# Altium schematic sheet GOST_A3_2 (1).SchDot
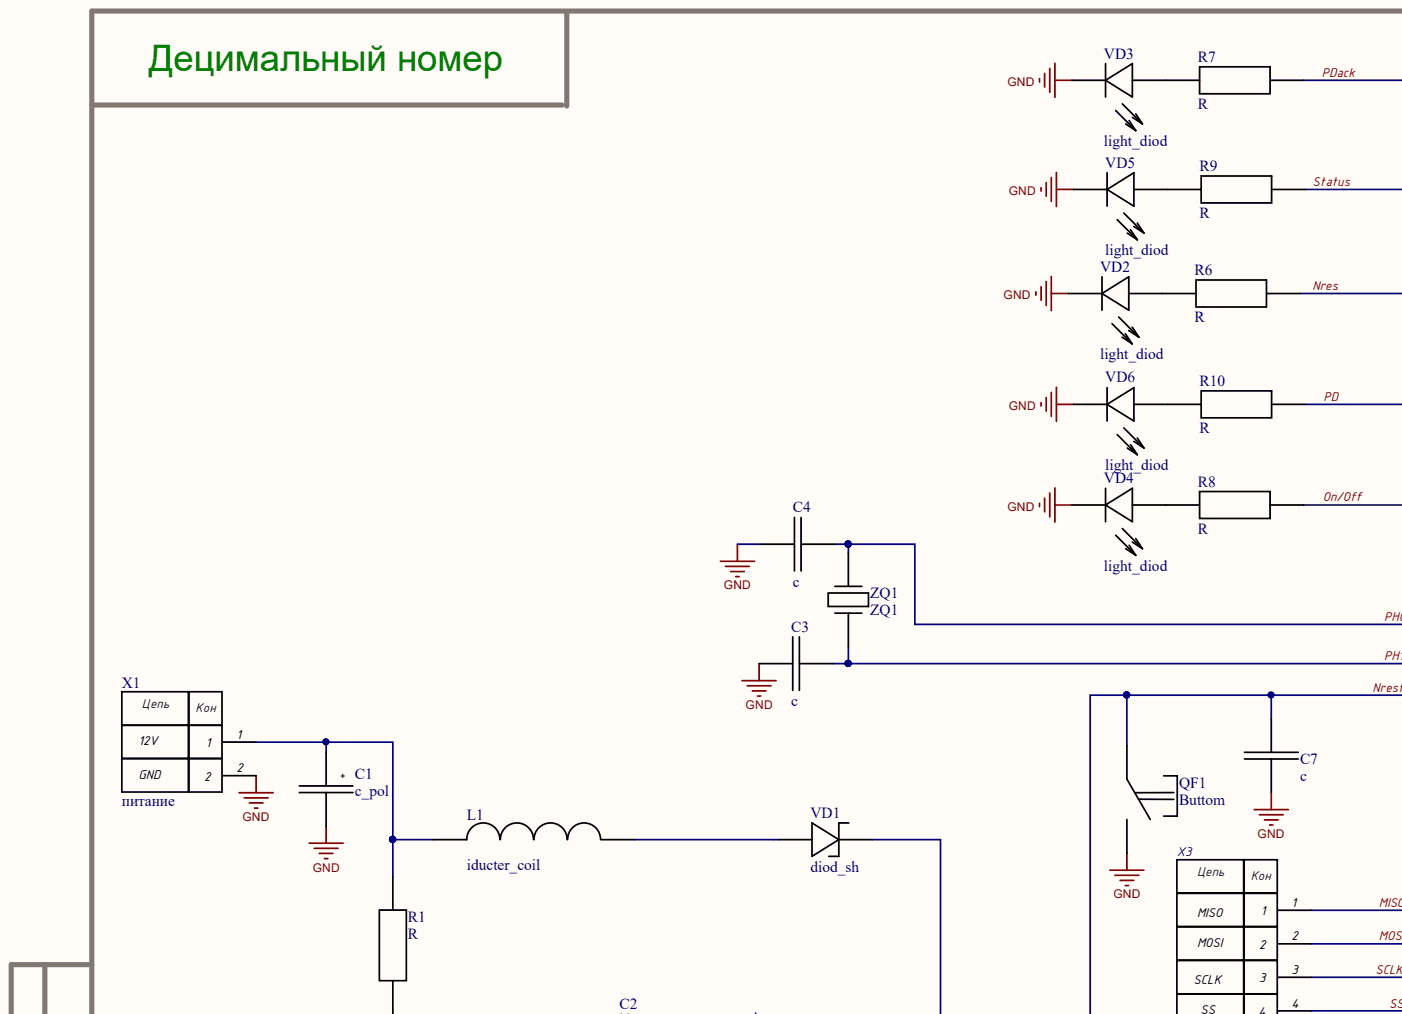
[diagram p0: GOST_A3_2 (1).SchDot, top left]
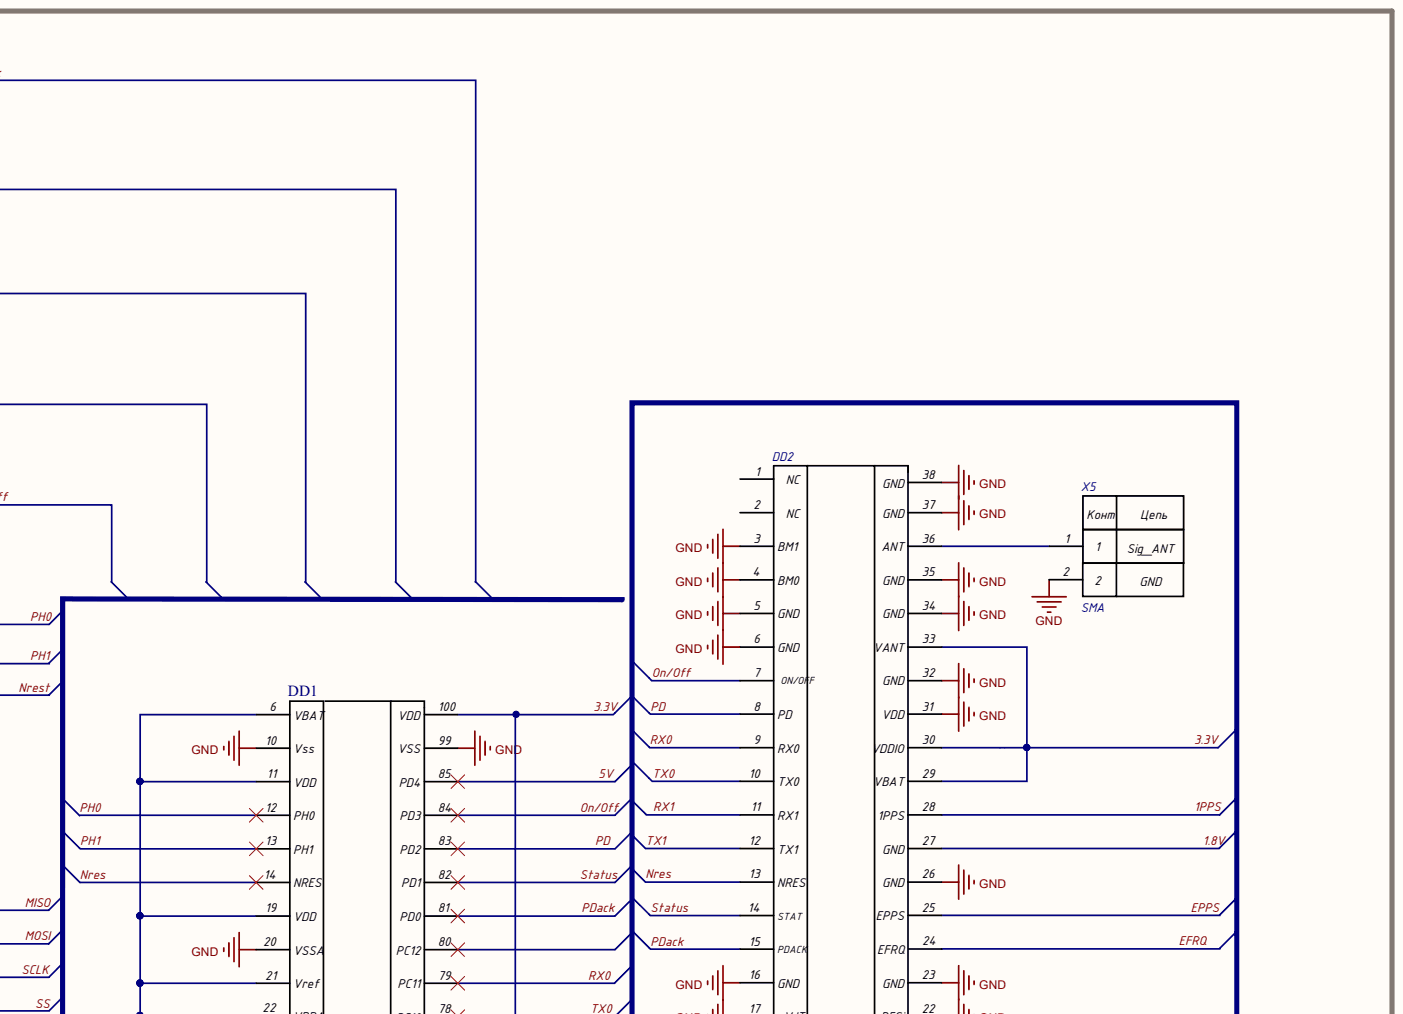
[diagram p1: GOST_A3_2 (1).SchDot, top right]
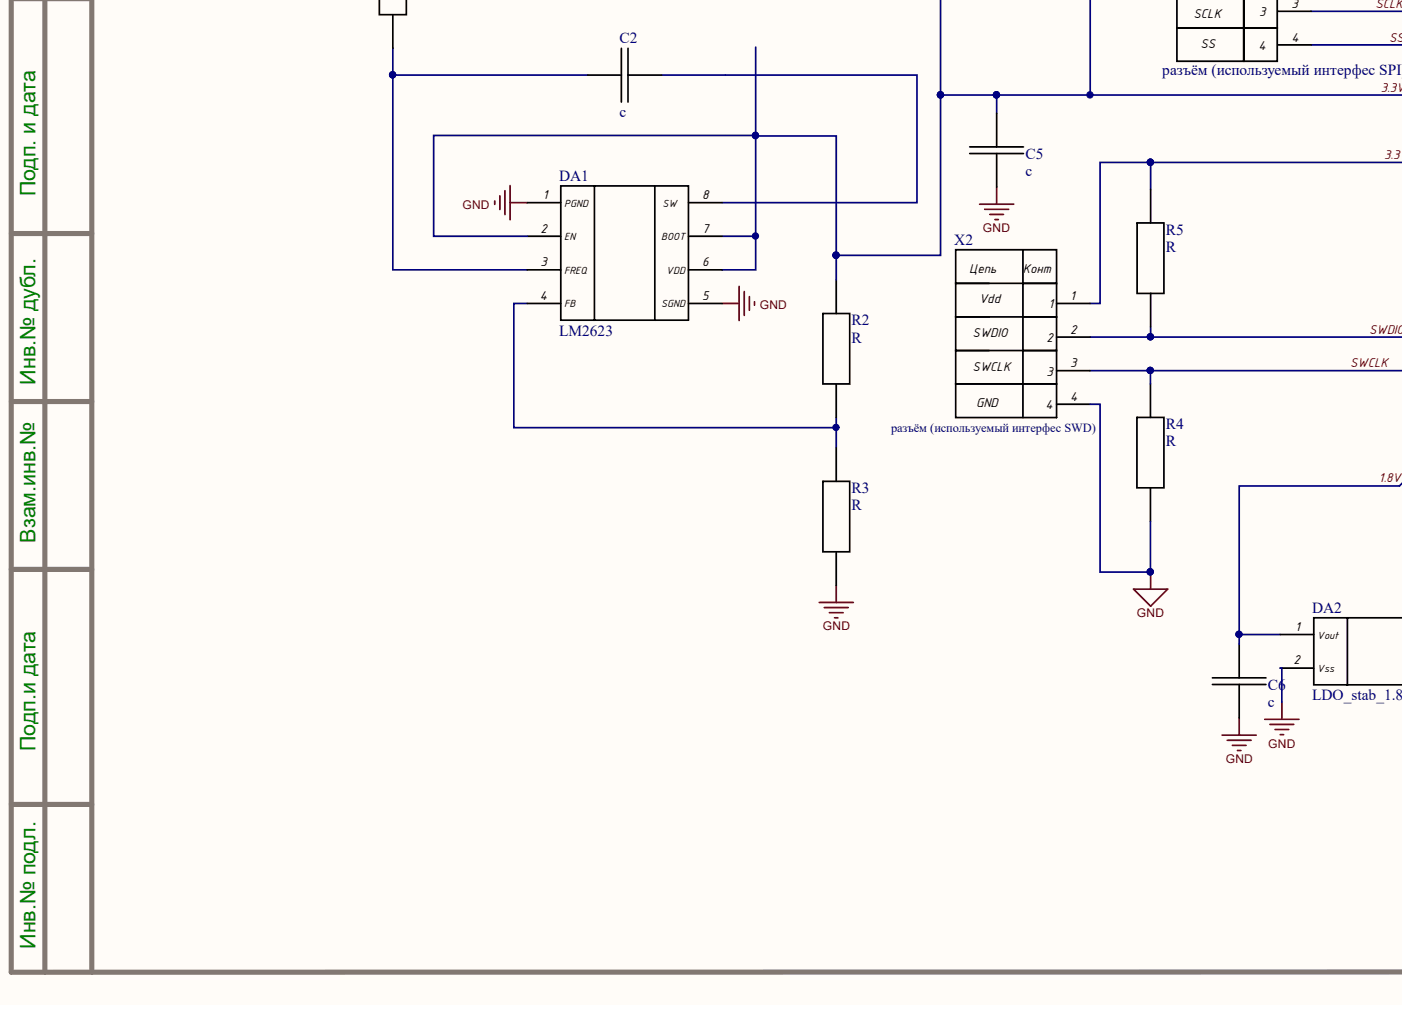
[diagram p2: GOST_A3_2 (1).SchDot, bottom left]
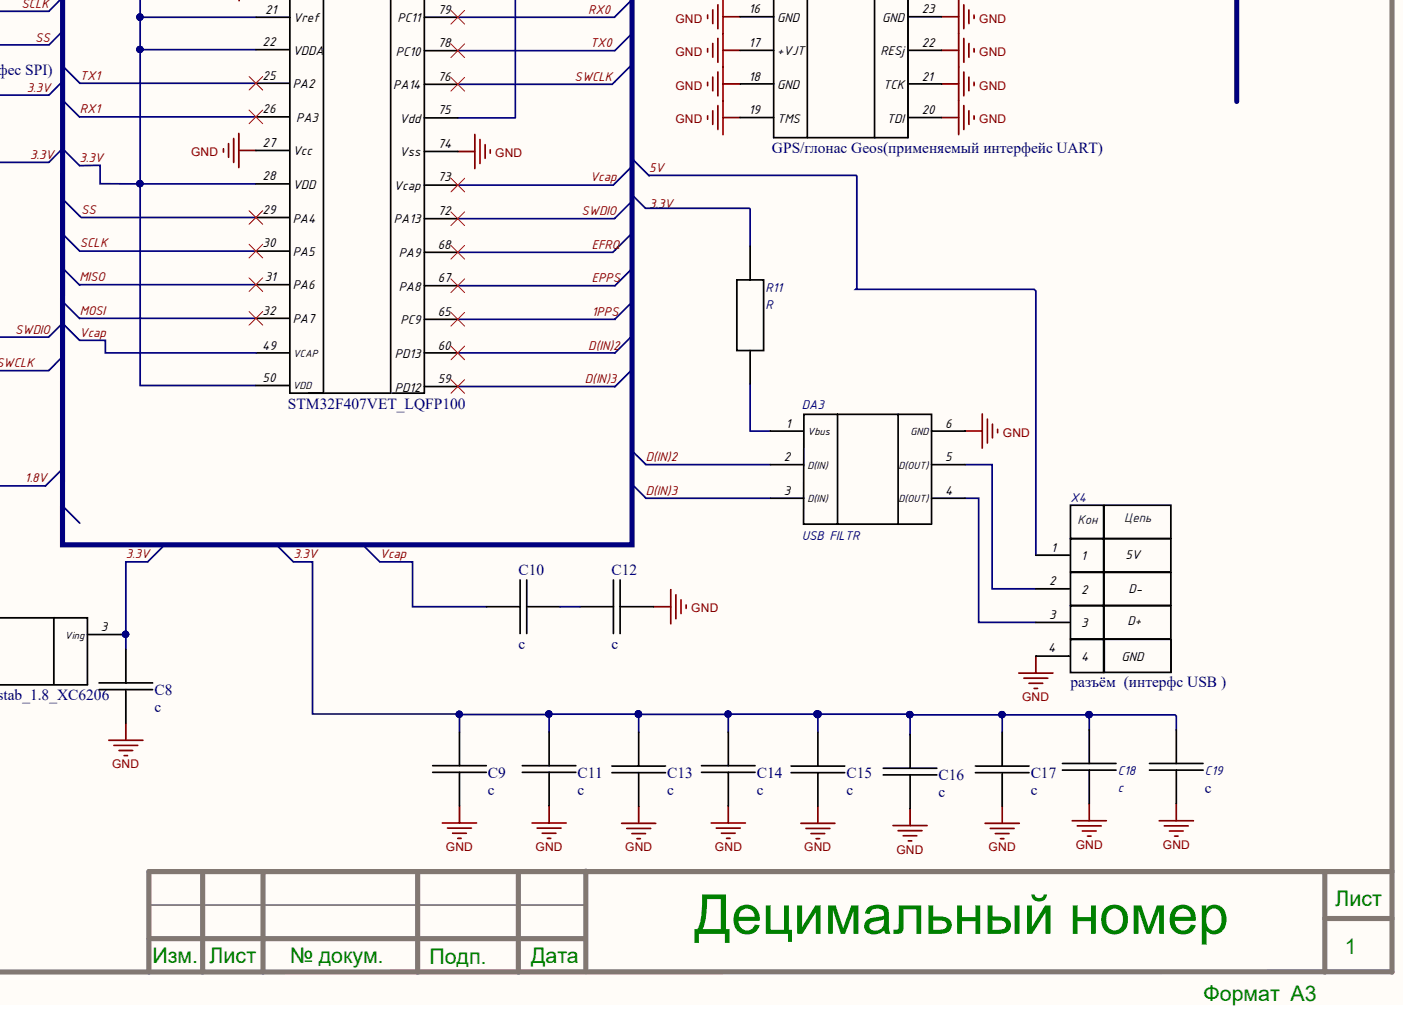
[diagram p3: GOST_A3_2 (1).SchDot, bottom right]

## Parts
C1  c_pol
C2  c
C3  c
C4  c
C5  c
C6  c
C7  c
C8  c
C9  c
C10  c
C11  c
C12  c
C13  c
C14  c
C15  c
C16  c
C17  c
C18  c
C19  c
DA1  LM2623
DA2  LDO_stab_1.8_XC6206
DA3  USB FILTR
DD1  STM32F407VET_LQFP100
DD2  GPS/глонас Geos(применяемый интерфейс UART)
L1  iducter_coil
QF1  Buttom
R1  R
R2  R
R3  R
R4  R
R5  R
R6  R
R7  R
R8  R
R9  R
R10  R
R11  R
VD1  diod_sh
VD2  light_diod
VD3  light_diod
VD4  light_diod
VD5  light_diod
VD6  light_diod
X1  питание
X2  разъём (используемый интерфес SWD)
X3  разъём (используемый интерфес SPI)
X4  разъём  (интерфс USB )
X5  SMA
ZQ1  ZQ1

## Nets
GND: C1.2 C3.1 C4.1 C5.2 C6.2 C7.2 C8.2 C9.2 C11.2 C12.2 C13.2 C14.2 C15.2 C16.2 C17.2 C18.2 C19.2 DA1.1(PGND) DA1.5(SGND) DA2.2 DA3.6(GND) DD1.10(Vss) DD1.20(VSSA) DD1.27(Vcc) DD1.74(Vss) DD1.99(VSS) DD2.3(BM1) DD2.4(BM0) DD2.5(GND) DD2.6(GND) DD2.16(GND) DD2.17(+VJT) DD2.18(GND) DD2.19(TMS) DD2.20(TDI) DD2.21(TCK) DD2.22(RESj) DD2.23(GND) DD2.26(GND) DD2.31(VDD) DD2.32(GND) DD2.34(GND) DD2.35(GND) DD2.37(GND) DD2.38(GND) QF1.2 R3.1 R4.1 VD2.2 VD3.2 VD4.2 VD5.2 VD6.2 X1.2 X2.4 X4.4 X5.2
1.8V: C6.1 DA2.1 DD2.27(GND)
1PPS: DD1.65(PC9) DD2.28(1PPS)
3.3V: C5.1 C7.1 C8.1 C9.1 C11.1 C13.1 C14.1 C15.1 C16.1 C17.1 C18.1 C19.1 DA1.2(EN) DA1.6(VDD) DA1.7(BOOT) DA2.3 DD1.6(VBAT) DD1.11(VDD) DD1.19(VDD) DD1.21(Vref) DD1.22(VDDA) DD1.28(VDD) DD1.50(VDD) DD1.75(Vdd) DD1.100(VDD) DD2.29(VBAT) DD2.30(VDDIO) DD2.33(VANT) QF1.1 R2.2 R5.2 VD1.2 X2.1
5V: DD1.85(PD4) X4.1
D(IN)2: DA3.2(D(IN)) DD1.60(PD13)
D(IN)3: DA3.3(D(IN)) DD1.59(PD12)
EFRQ: DD1.68(PA9) DD2.24(EFRQ)
EPPS: DD1.67(PA8) DD2.25(EPPS)
MISO: DD1.31(PA6) X3.1
MOSI: DD1.32(PA7) X3.2
NRES: DD1.14(NRES) DD2.13(NRES) R6.2
ON/OFF: DD1.84(PD3) DD2.7(ON/OFF) R8.2
PD: DD1.83(PD2) DD2.8(PD) R10.2
PDACK: DD1.81(PD0) DD2.15(PDACK) R7.2
PH0: C4.2 DD1.12(PH0) ZQ1.1
PH1: C3.2 DD1.13(PH1) ZQ1.2
RX0: DD1.79(PC11) DD2.9(RX0)
RX1: DD1.26(PA3) DD2.11(RX1)
SCLK: DD1.30(PA5) X3.3
SS: DD1.29(PA4) X3.4
STATUS: DD1.82(PD1) DD2.14(STAT) R9.2
SWCLK: DD1.76(PA14)
SWDIO: DD1.72(PA13) R5.1 X2.2
SWСLK: R4.2 X2.3
TX0: DD1.78(PC10) DD2.10(TX0)
TX1: DD1.25(PA2) DD2.12(TX1)
VCAP: C10.1 DD1.49(VCAP) DD1.73(Vcap)
NetC1_1: C1.1 L1.1 R1.2 X1.1
NetC2_1: C2.1 DA1.3(FREQ) R1.1
NetC2_2: C2.2 DA1.8(SW)
NetC10_2: C10.2 C12.1
NetDA1_4: DA1.4(FB) R2.1 R3.2
NetDA3_1: DA3.1(Vbus) R11.1
NetDA3_4: DA3.4(D(OUT)) X4.3
NetDA3_5: DA3.5(D(OUT)) X4.2
NetDD2_36: DD2.36(ANT) X5.1
NetL1_2: L1.2 VD1.1
NetR6_1: R6.1 VD2.1
NetR7_1: R7.1 VD3.1
NetR8_1: R8.1 VD4.1
NetR9_1: R9.1 VD5.1
NetR10_1: R10.1 VD6.1
N00012:

Not connected: DD1 80(PC12); DD2 1(NC) 2(NC); R11 2
